# Altium schematic sheet MooresGlobe r4.SchDoc
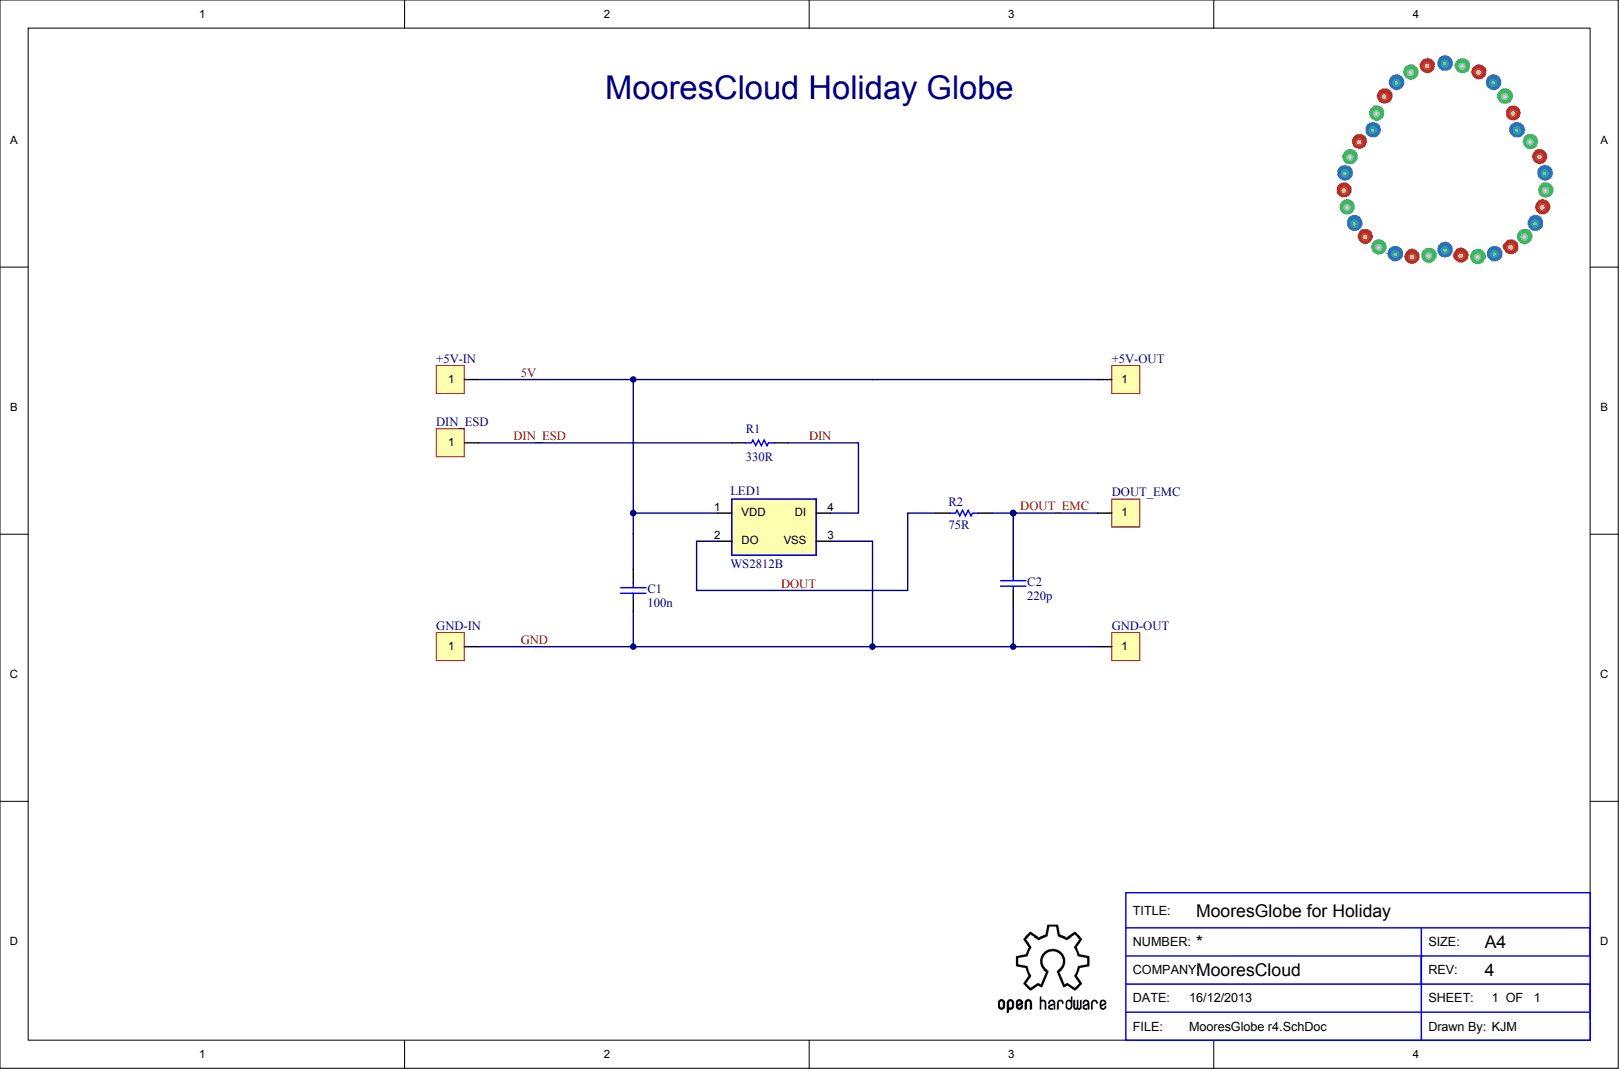
[diagram p0: MooresGlobe r4.SchDoc]

## Parts
+5V-IN  +5V
+5V-OUT  +5V
C1  100n
C2  220p
DIN_ESD  DIN_ESD
DOUT_EMC  DOUT_EMC
GND-IN  GND
GND-OUT  GND
LED1  WS2812B
R1  330R
R2  75R

## Nets
5V: +5V-IN.1 +5V-OUT.1 C1.1 LED1.1(VDD)
DIN: LED1.4(DI) R1.1
DIN_ESD: DIN_ESD.1 R1.2
DOUT: LED1.2(DO) R2.1
DOUT_EMC: C2.1 DOUT_EMC.1 R2.2
GND: C1.2 C2.2 GND-IN.1 GND-OUT.1 LED1.3(VSS)

## Library data of the parts
C1 = (no symbol name): 1.Manufacturer: Yageo; 4.CatNo: 311-1343-2-ND; 5.Note: Ceramic 50V Y5V -20%/+80%; 2.MfgNo: CC0603ZRY5V9BB104; 9.UnitCost: 0.00206; 3.Supplier: DigiKey
C2 = (no symbol name): 1.Manufacturer: Samsung; 4.CatNo: 311-1520-2-ND; 5.Note: Ceramic 50V NP0 5%; 2.MfgNo: CL10C221JB8NNNC; 9.UnitCost: 0.00570; 3.Supplier: DigiKey
LED1 = (no symbol name): 1.Manufacturer: WorldSemi; 9.UnitCost: 0.15; 2.MfgNo: WS2812B; 3.Supplier: WorldSemi; 4.CatNo: WS2812B
R1 = (no symbol name): 1.Manufacturer: Yageo; 4.CatNo: 311-330GRTR-ND; 2.MfgNo: RC0603JR-07330RL; 9.UnitCost: 0.00092; 5.Note: Thick Film 5% 0.1W; 3.Supplier: DigiKey
R2 = (no symbol name): 1.Manufacturer: Yageo; 4.CatNo: 311-75GRTR-ND; 2.MfgNo: RC0603JR-0775RL; 9.UnitCost: 0.00092; 5.Note: Thick Film 5% 0.1W; 3.Supplier: DigiKey
